# Altium schematic sheet Debugger.SchDoc(Debugger)
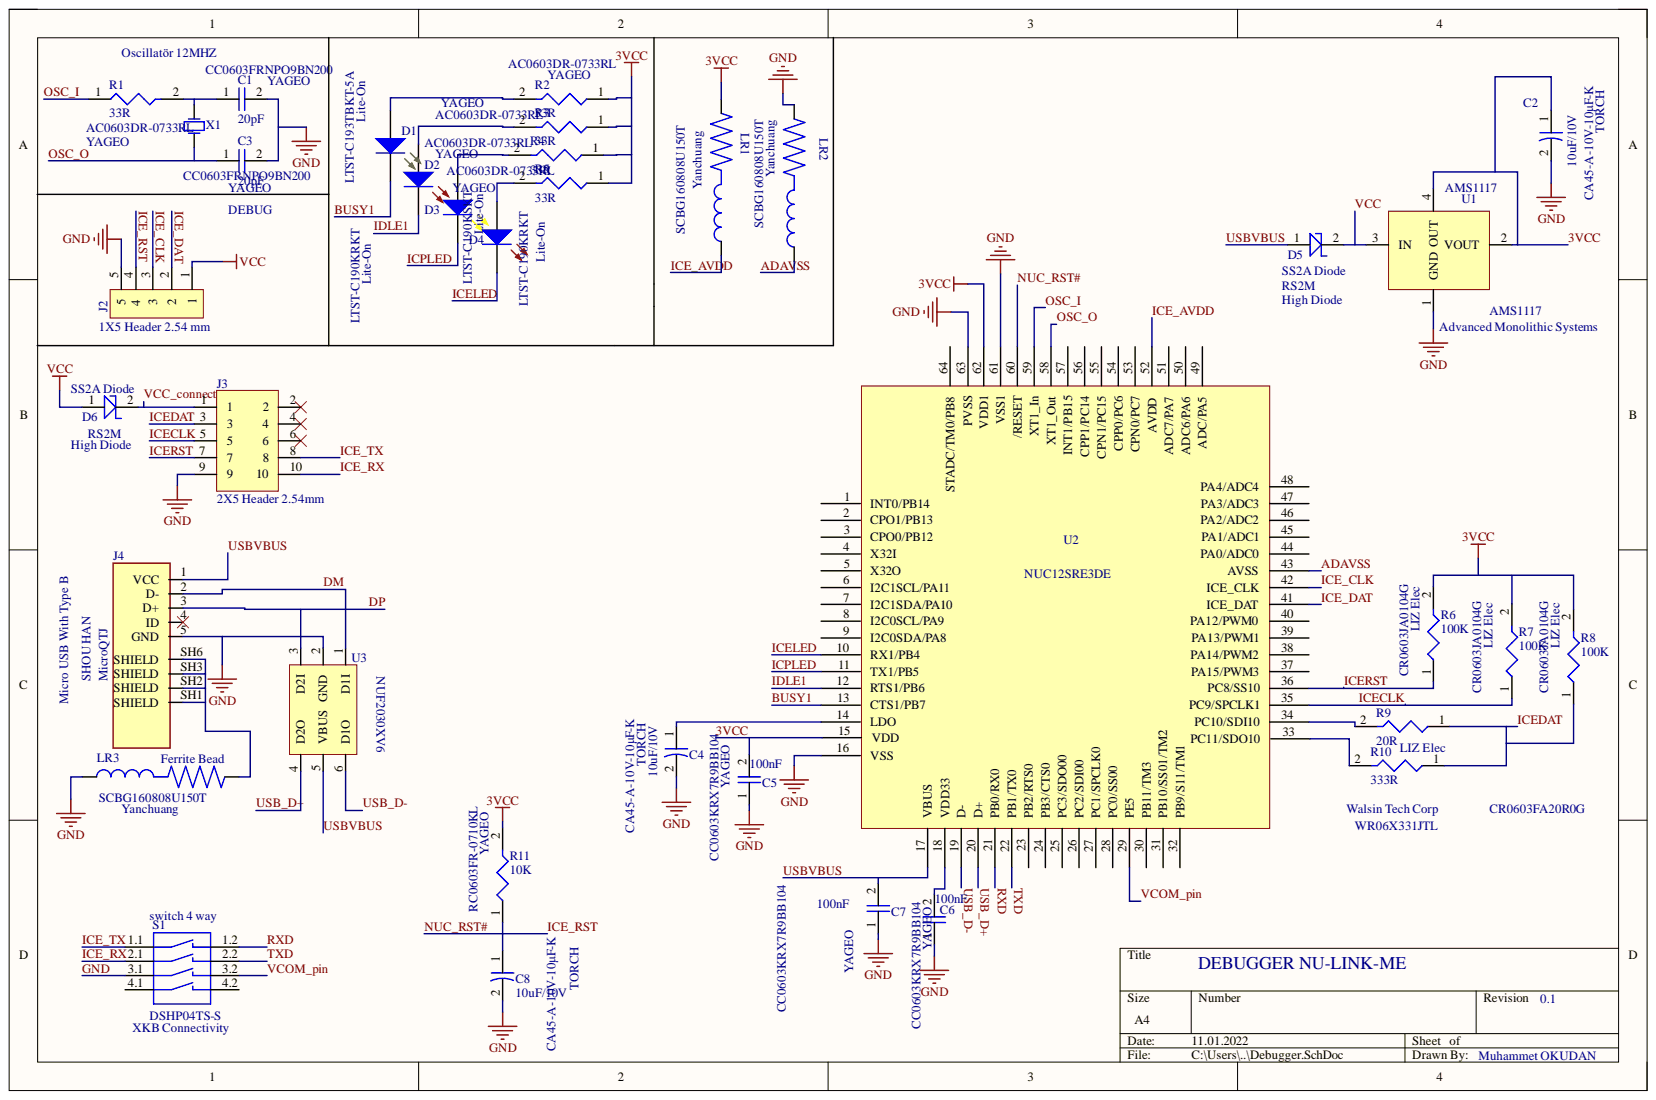
[diagram p0: Debugger.SchDoc]

## Parts
C1  20pF  *Mfg Part#: CC0603FRNPO9BN200  Manufacturer: YAGEO  [CAP 0603]
C2  10uF/10V  *Mfg Part#: CA45-A-10V-10μF-K  [T491A104K035AT TANTAL CAP]
C3  20pF  *Mfg Part#: CC0603FRNPO9BN200  Manufacturer: YAGEO  [CAP 0603]
C4  10uF/10V  Manufacturer: TORCH  [T491A104K035AT TANTAL CAP]
C5  100nF  Manufacturer: YAGEO  [CAP 0603]
C6  100nF  *Mfg Part#: CC0603KRX7R9BB104  Manufacturer: YAGEO  [CAP 0603]
C7  100nF  Manufacturer: YAGEO  [CAP 0603]
C8  10uF/10V  [T491A104K035AT TANTAL CAP]
D1  *Mfg Part#: LTST-C193TBKT-5A  Manufacturer: Lite-On  [LED Green]  (hidden comment: LED Green)
D2  Manufacturer: Lite-On  [LED Red]  (hidden comment: Led Red)
D3  *Mfg Part#: LTST-C190KSKT  Manufacturer: Lite-On  [LED Yellow]  (hidden comment: LED Yellow)
D4  *Mfg Part#: LTST-C190KRKT  Manufacturer: Lite-On  [LED Red]  (hidden comment: Led Red)
D5  SS2A Diode  *Mfg Part#: RS2M  [Diode SS2A]
D6  SS2A Diode  *Mfg Part#: RS2M  Manufacturer: High Diode  [Diode SS2A]
J2  1X5 Header 2.54 mm  [1x5 header 2.54mm]
J3  2X5 Header 2.54mm  [2x5 header 2.54mm]
J4  Micro USB With Type B  *Mfg Part#: MicroQTJ  Manufacturer: SHOU HAN  [Micro USB with type B]
LR1  Ferrite Bead  *Mfg Part#: SCBG160808U150T  Manufacturer: Yanchuang  [FERRITE BEAD 0603]
LR2  Ferrite Bead  Manufacturer: Yanchuang  [FERRITE BEAD 0603]
LR3  Ferrite Bead  *Mfg Part#: SCBG160808U150T  Manufacturer: Yanchuang  [FERRITE BEAD 0603]
R1  33R  [0603 RES]
R2  33R  *Mfg Part#: AC0603DR-0733RL  Manufacturer: YAGEO  [0603 RES]
R3  33R  *Mfg Part#: AC0603DR-0733RL  [0603 RES]
R4  33R  *Mfg Part#: AC0603DR-0733RL  [0603 RES]
R5  33R  *Mfg Part#: AC0603DR-0733RL  [0603 RES]
R6  100K  *Mfg Part#: CR0603JA0104G  Manufacturer: LIZ Elec  [0603 RES]
R7  100K  *Mfg Part#: CR0603JA0104G  Manufacturer: LIZ Elec  [0603 RES]
R8  100K  Manufacturer: LIZ Elec  [0603 RES]
R9  20R  Manufacturer: LIZ Elec  [0603 RES]
R10  333R  [0603 RES]
R11  10K  *Mfg Part#: RC0603FR-0710KL  Manufacturer: YAGEO  [0603 RES]
S1  switch 4 way  *Mfg Part#: DSHP04TS-S  Manufacturer: XKB Connectivity  [KLS7-KPR]
U1  AMS1117  [SOT223]
U2  NUC12SRE3DE  [LQFP50P1200X1200X185-64N]
U3  NUF2030XV6  [NUF2030XV6]
X1  Crystal Oscillatör  [Crystal Oscillatör]  (value not drawn)

## Nets
3VCC: C2.1 C5.2 LR1.2 R2.1 R3.1 R4.1 R5.1 R6.2 R7.2 R8.2 R11.2 U1.2(VOUT) U1.4(OUT) U2.15(VDD) U2.62(VDD1)
ADAVSS: LR2.1 U2.43(AVSS)
BUSY1: D1.2 U2.13(CTS1/PB7)
DM: J4.2(D-) U3.1(D1I)
DP: J4.3(D+) U3.3(D2I)
GND: C1.2 C2.2 C3.2 C4.2 C5.1 C6.1 C7.1 C8.2 J2.5 J3.9 J4.5(GND) LR2.2 LR3.1 S1.3.1 U1.1(GND) U2.16(VSS) U2.61(VSS1) U2.63(PVSS) U3.2(GND)
ICE_AVDD: LR1.1 U2.52(AVDD)
ICE_CLK: J2.3 U2.42(ICE_CLK)
ICE_DAT: J2.2 U2.41(ICE_DAT)
ICE_RX: J3.10 S1.2.1
ICE_TX: J3.8 S1.1.1
ICECLK: J3.5 R7.1 U2.35(PC9/SPCLK1)
ICEDAT: J3.3 R8.1 R9.1 R10.1
ICELED: D4.2 U2.10(RX1/PB4)
ICERST: J3.7 R6.1 U2.36(PC8/SS10)
ICPLED: D3.2 U2.11(TX1/PB5)
IDLE1: D2.2 U2.12(RTS1/PB6)
NUC_RST#: C8.1 J2.4 R11.1 U2.60(/RESET)
OSC_I: R1.1 U2.59(XT1_In)
OSC_O: C3.1 U2.58(XT1_Out) X1.2
RXD: S1.1.2 U2.21(PB0/RX0)
TXD: S1.2.2 U2.22(PB1/TX0)
USB_D+: U2.20(D+) U3.4(D2O)
USB_D-: U2.19(D-) U3.6(D1O)
USBVBUS: C7.2 D5.1 J4.1(VCC) U2.17(VBUS) U3.5(VBUS)
VCC: D5.2 D6.1 J2.1 U1.3(IN)
VCC_connect: D6.2 J3.1
VCOM_pin: S1.3.2 U2.29(PE5)
NetC1_1: C1.1 R1.2 X1.1
NetC4_1: C4.1 U2.14(LDO)
NetC6_2: C6.2 U2.18(VDD33)
NetD1_1: D1.1 R2.2
NetD2_1: D2.1 R3.2
NetD3_1: D3.1 R4.2
NetD4_1: D4.1 R5.2
NetJ4_SH1: J4.SH1(SHIELD) J4.SH2(SHIELD) J4.SH3(SHIELD) J4.SH6(SHIELD) LR3.2
NetR9_2: R9.2 U2.34(PC10/SDI10)
NetR10_2: R10.2 U2.33(PC11/SDO10)

Not connected: J3 2 4 6; J4 4(ID); S1 4.1 4.2; U2 1(INT0/PB14) 2(CPO1/PB13) 3(CPO0/PB12) 4(X32I) 5(X32O) 6(I2C1SCL/PA11) 7(I2C1SDA/PA10) 8(I2C0SCL/PA9) 9(I2C0SDA/PA8) 23(PB2/RTS0) 24(PB3/CTS0) 25(PC3/SDO00) 26(PC2/SDI00) 27(PC1/SPCLK0) 28(PC0/SS00) 30(PB11/TM3) 31(PB10/SS01/TM2) 32(PB9/S11/TM1) 37(PA15/PWM3) 38(PA14/PWM2) 39(PA13/PWM1) 40(PA12/PWM0) 44(PA0/ADC0) 45(PA1/ADC1) 46(PA2/ADC2) 47(PA3/ADC3) 48(PA4/ADC4) 49(ADC/PA5) 50(ADC6/PA6) 51(ADC7/PA7) 53(CPN0/PC7) 54(CPP0/PC6) 55(CPN1/PC15) 56(CPP1/PC14) 57(INT1/PB15) 64(STADC/TM0/PB8)

## Library data of the parts
C1 C3 C6 = 0603 CAP: Component Kind: Standard; Library Name: NUV.SchLib
C2 = 0603 TANTAL CAP: TANTAL CAP 0603; Manufacturer: TORCH; Component Kind: Standard; Library Name: NUV.SchLib
C4 = 0603 TANTAL CAP: TANTAL CAP 0603; *Mfg Part#: CA45-A-10V-10μF-K; Component Kind: Standard; Library Name: NUV.SchLib
C5 C7 = 0603 CAP: *Mfg Part#: CC0603KRX7R9BB104; Component Kind: Standard; Library Name: NUV.SchLib
C8 = 0603 TANTAL CAP: TANTAL CAP 0603; *Mfg Part#: CA45-A-10V-10μF-K; Manufacturer: TORCH; Component Kind: Standard; Library Name: NUV.SchLib
D2 = Led Red: *Mfg Part#: LTST-C190KRKT; Component Kind: Standard; Description: led red; Library Name: NUV.SchLib
D5 = SS2A Diode: Manufacturer: High Diode; Component Kind: Standard; Library Name: NUV.SchLib
LR1 LR3 = Ferrite Bead: 0603 FERRITE BEAD; Component Kind: Standard; Library Name: NUV.SchLib
LR2 = Ferrite Bead: 0603 FERRITE BEAD; *Mfg Part#: SCBG160808U150T; Component Kind: Standard; Library Name: NUV.SchLib
R1 = 0603 RES: *Mfg Part#: AC0603DR-0733RL; Manufacturer: YAGEO; Component Kind: Standard; Library Name: NUV.SchLib
R2 R6 R7 R11 = 0603 RES: Component Kind: Standard; Library Name: NUV.SchLib
R3-R5 = 0603 RES: Manufacturer: YAGEO; Component Kind: Standard; Library Name: NUV.SchLib
R8 = 0603 RES: *Mfg Part#: CR0603JA0104G; Component Kind: Standard; Library Name: NUV.SchLib
R9 = 0603 RES: *Mfg Part#: CR0603FA20R0G; Component Kind: Standard; Library Name: NUV.SchLib
R10 = 0603 RES: *Mfg Part#: WR06X331JTL; Manufacturer: Walsin Tech Corp; Component Kind: Standard; Library Name: NUV.SchLib
U1 = AMS1117: Manufacturer: Advanced Monolithic Systems; *Mfg Part#: AMS1117; Component Kind: Standard; Library Name: NUV.SchLib
U2 = NUC12SRE3DE: Integrated Circuit for programming nuvaton; Component Kind: Standard; Library Name: NUV.SchLib
X1 = Crystal Oscillatör: 12Mhz; *Mfg Part#: XIHCELNANF-12MHZ; Manufacturer: TAITIEN Elec; Component Kind: Standard; Library Name: NUV.SchLib
D1 = LED Green: Component Kind: Standard; Description: Led Green; Library Name: NUV.SchLib
D3 = LED Yellow: Component Kind: Standard; Description: Led Yellow; Library Name: NUV.SchLib
D4 = Led Red: Component Kind: Standard; Description: led red; Library Name: NUV.SchLib
D6 = SS2A Diode: Component Kind: Standard; Library Name: NUV.SchLib
J2 = 1X5 Header 2.54 mm: Component Kind: Standard; Library Name: NUV.SchLib
J3 = 2X5 Header 2.54mm: Component Kind: Standard; Library Name: NUV.SchLib
J4 = Micro USB With Type B: Component Kind: Standard; Library Name: NUV.SchLib
S1 = switch 4 way: Component Kind: Standard; Library Name: NUV.SchLib
U3 = NUF2030XV6: Component Kind: Standard; Library Name: NUV.SchLib
